# Altium schematic sheet User_inputs.SchDoc(User_inputs)
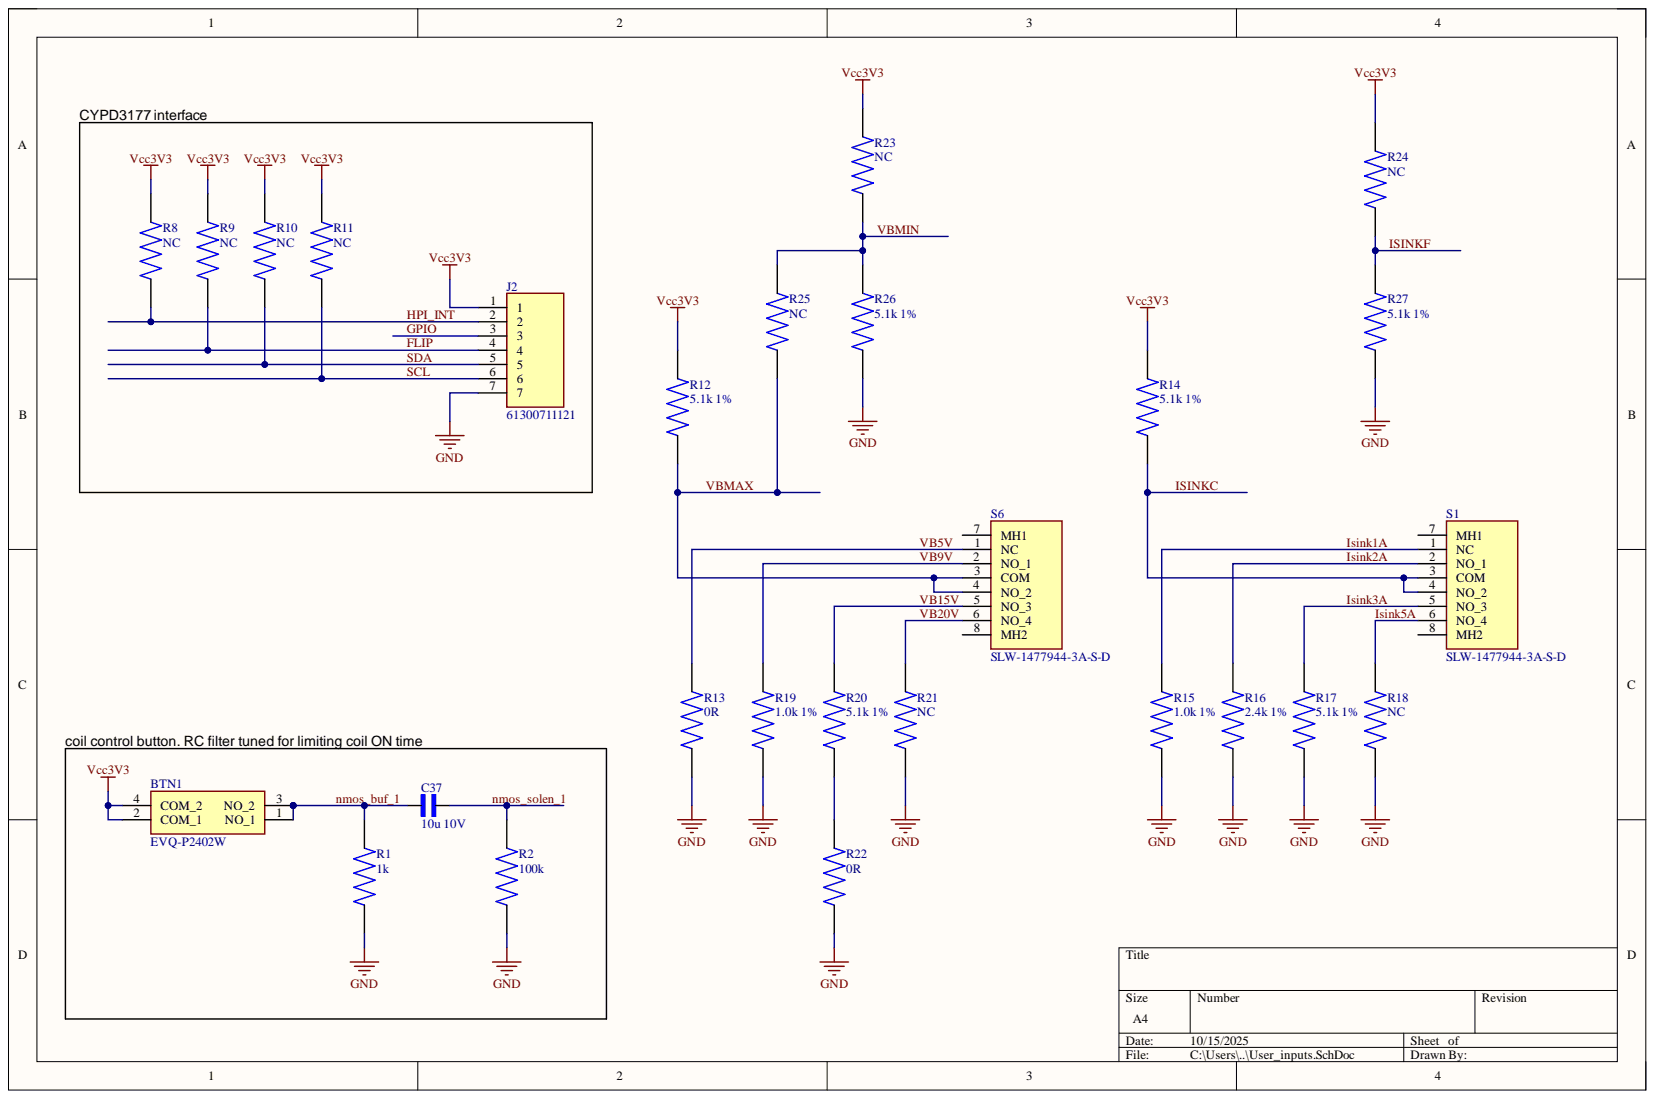
[diagram p0: User_inputs.SchDoc]

## Parts
BTN1  EVQ-P2402W  [EVQP2402W]
C37  10u 10V  [cap_1206]
J2  61300711121  [HDRV7W64P0X254_1X7_1778X254X869P]
R1  1k  [res_1206]
R2  100k  [res_1206]
R8  NC  [res_1206]
R9  NC  [res_1206]
R10  NC  [res_1206]
R11  NC  [res_1206]
R12  5.1k 1%  [res_1206]
R13  0R  [res_1206]
R14  5.1k 1%  [res_1206]
R15  1.0k 1%  [res_1206]
R16  2.4k 1%  [res_1206]
R17  5.1k 1%  [res_1206]
R18  NC  [res_1206]
R19  1.0k 1%  [res_1206]
R20  5.1k 1%  [res_1206]
R21  NC  [res_1206]
R22  0R  [res_1206]
R23  NC  [res_1206]
R24  NC  [res_1206]
R25  NC  [res_1206]
R26  5.1k 1%  [res_1206]
R27  5.1k 1%  [res_1206]
S1  SLW-1477944-3A-S-D  [SLW14779443ASD]
S6  SLW-1477944-3A-S-D  [SLW14779443ASD]

## Nets
GND: J2.7 R1.1 R2.1 R13.1 R15.1 R16.1 R17.1 R18.1 R19.1 R21.1 R22.1 R26.1 R27.1
Vcc3V3: BTN1.2(COM_1) BTN1.4(COM_2) J2.1 R8.2 R9.2 R10.2 R11.2 R12.2 R14.2 R23.2 R24.2
FLIP: J2.4 R9.1
GPIO: J2.3
HPI_INT: J2.2 R8.1
Isink1A: R15.2 S1.1(NC)
Isink2A: R16.2 S1.2(NO_1)
Isink3A: R17.2 S1.5(NO_3)
Isink5A: R18.2 S1.6(NO_4)
ISINKC: R14.1 S1.3(COM) S1.4(NO_2)
ISINKF: R24.1 R27.2
nmos_buf_1: BTN1.1(NO_1) BTN1.3(NO_2) C37.2 R1.2
nmos_solen_1: C37.1 R2.2
SCL: J2.6 R11.1
SDA: J2.5 R10.1
VB5V: R13.2 S6.1(NC)
VB9V: R19.2 S6.2(NO_1)
VB15V: R20.2 S6.5(NO_3)
VB20V: R21.2 S6.6(NO_4)
VBMAX: R12.1 R25.1 S6.3(COM) S6.4(NO_2)
VBMIN: R23.1 R25.2 R26.2
NetR20_1: R20.1 R22.2

Not connected: S1 7(MH1) 8(MH2); S6 7(MH1) 8(MH2)

## Library data of the parts
BTN1 = EVQ-P2402W: Switch; Manufacturer_Name: Panasonic; Arrow Part Number: EVQ-P2402W; Arrow Price/Stock: https://www.arrow.com/en/products/evq-p2402w/panasonic?utm_currency=USD&region=nac; Component Kind: Standard; Datasheet Link: https://componentsearchengine.com/Datasheets/1/EVQ-P2402W.pdf; Height: 2.7mm; Manufacturer_Part_Number: EVQ-P2402W; Mouser Part Number: 667-EVQ-P2402W; Mouser Price/Stock: https://www.mouser.co.uk/ProductDetail/Panasonic/EVQ-P2402W?qs=whhzBCqEXCvxHEhCrDZwwQ%3D%3D
C37 = cap_1206: Component Kind: Standard; Library Name: cap_1206.IntLib
J2 = 61300711121: Connector; Manufacturer_Name: Wurth Elektronik; Component Kind: Standard; Datasheet Link: http://katalog.we-online.de/em/datasheet/6130xx11121.pdf; Height: 8.69mm; Manufacturer_Part_Number: 61300711121; Mouser Part Number: 710-61300711121; Mouser Price/Stock: https://www.mouser.co.uk/ProductDetail/Wurth-Elektronik/61300711121?qs=PhR8RmCirEa4Kw6rJ18PJA%3D%3D
R1 R2 R8-R27 = res_1206: Component Kind: Standard; Library Name: cap_1206.IntLib
S1 S6 = SLW-1477944-3A-S-D: Switch; Manufacturer_Name: Same Sky; Component Kind: Standard; Datasheet Link: https://www.sameskydevices.com/product/resource/supplyframepdf/slw-1477944-3a-s-d.pdf; Height: 8mm; Library Name: SamacSys.SchLib; Manufacturer_Part_Number: SLW-1477944-3A-S-D; Mouser Part Number: 179-SLW14779443ASD; Mouser Price/Stock: https://www.mouser.co.uk/ProductDetail/CUI-Devices/SLW-1477944-3A-S-D?qs=IKkN%2F947nfD1CC%2FffJ%2FTNA%3D%3D
